# Altium schematic sheet ETHERNET.SchDoc(ETHERNET)
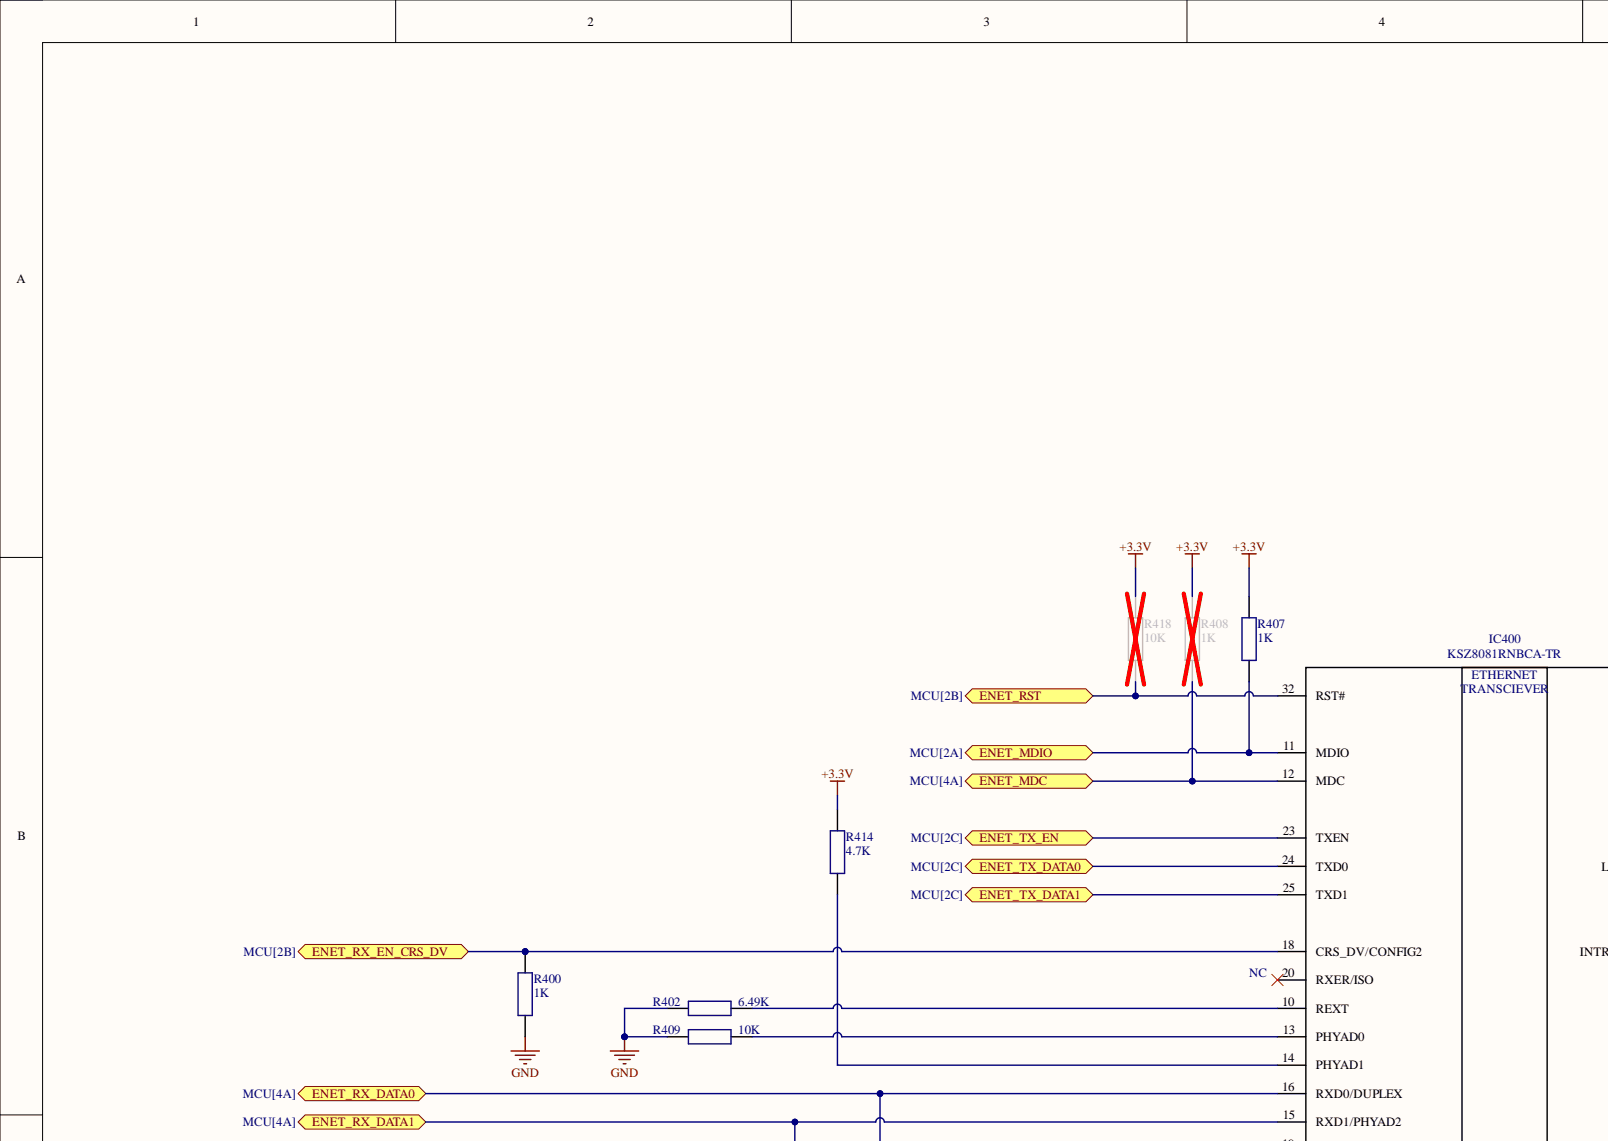
[diagram p0: ETHERNET.SchDoc, top left]
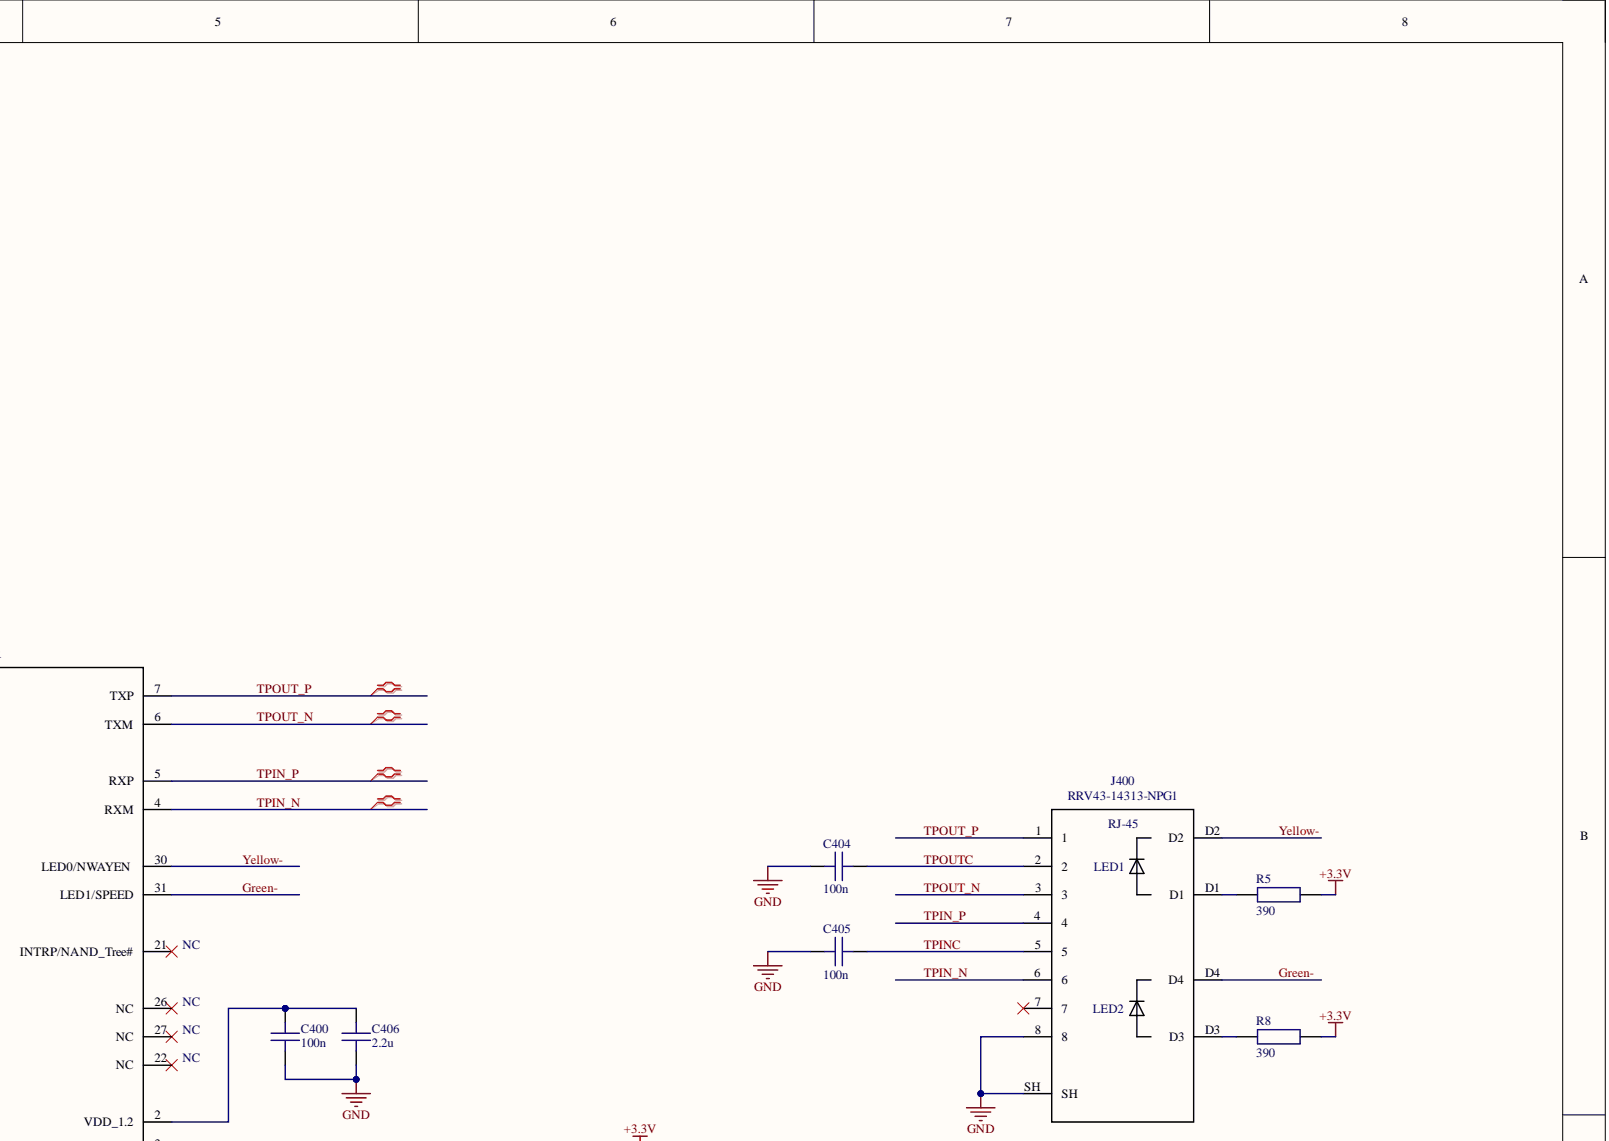
[diagram p1: ETHERNET.SchDoc, top right]
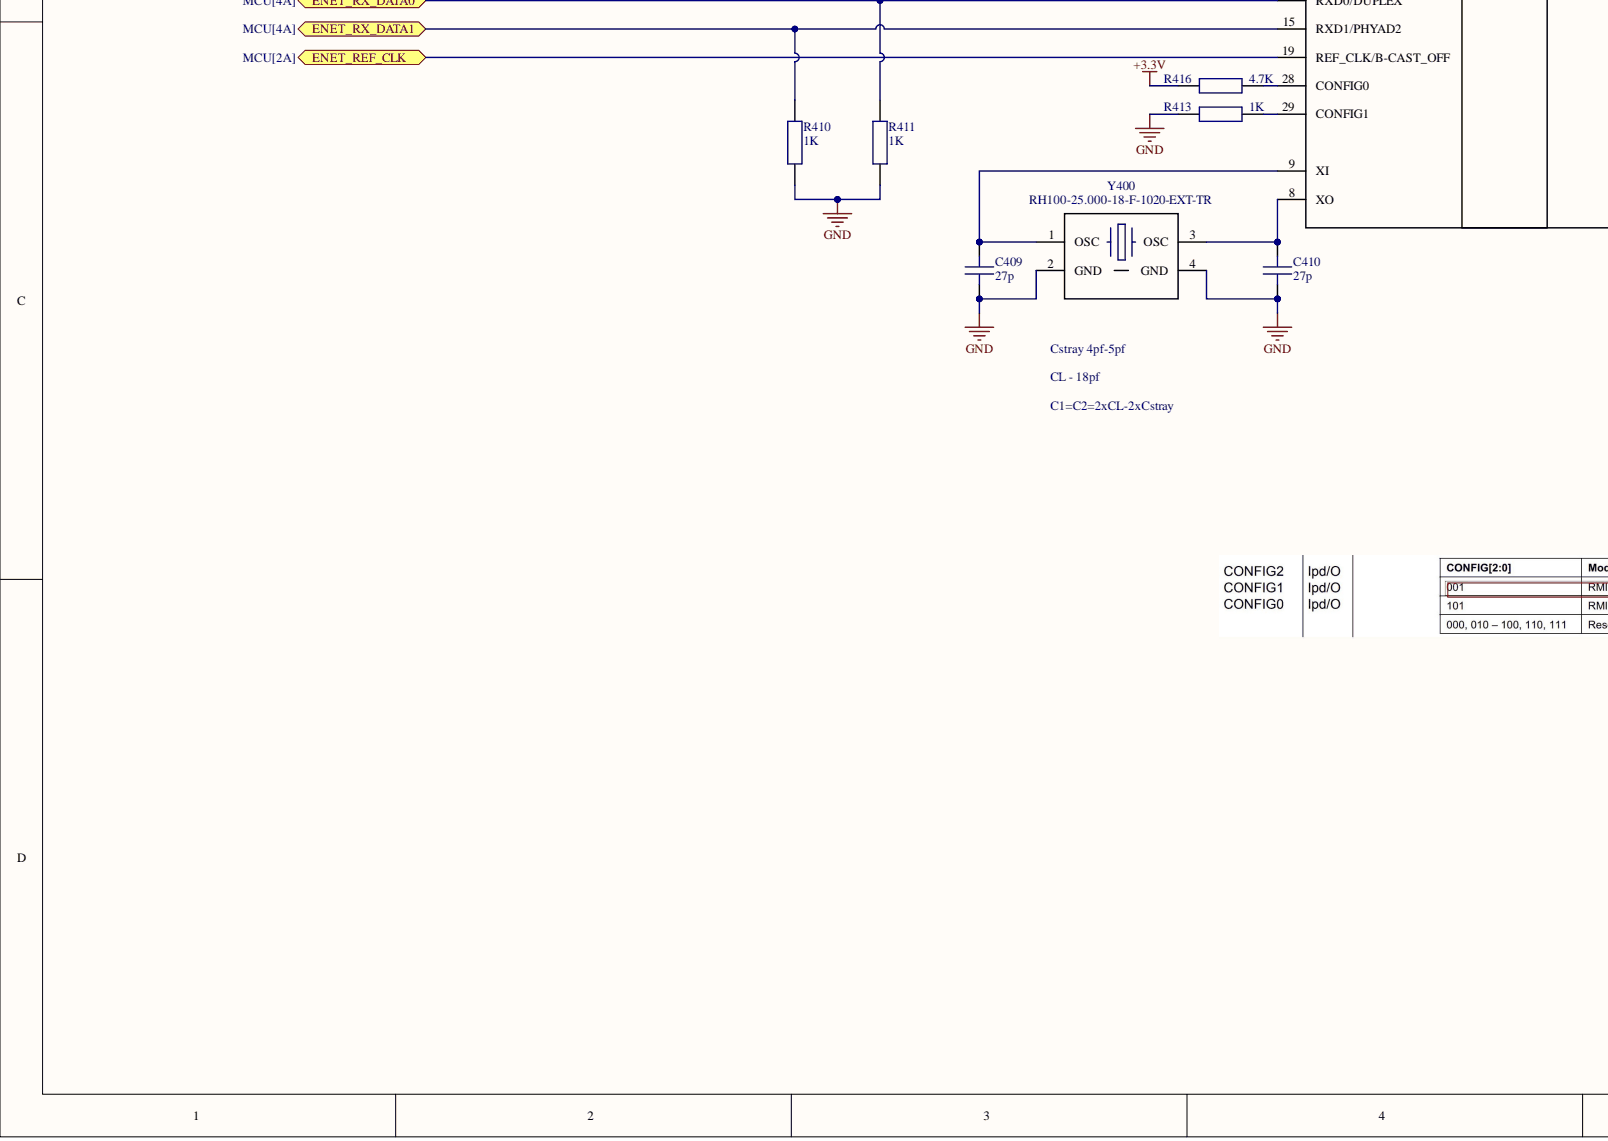
[diagram p2: ETHERNET.SchDoc, bottom left]
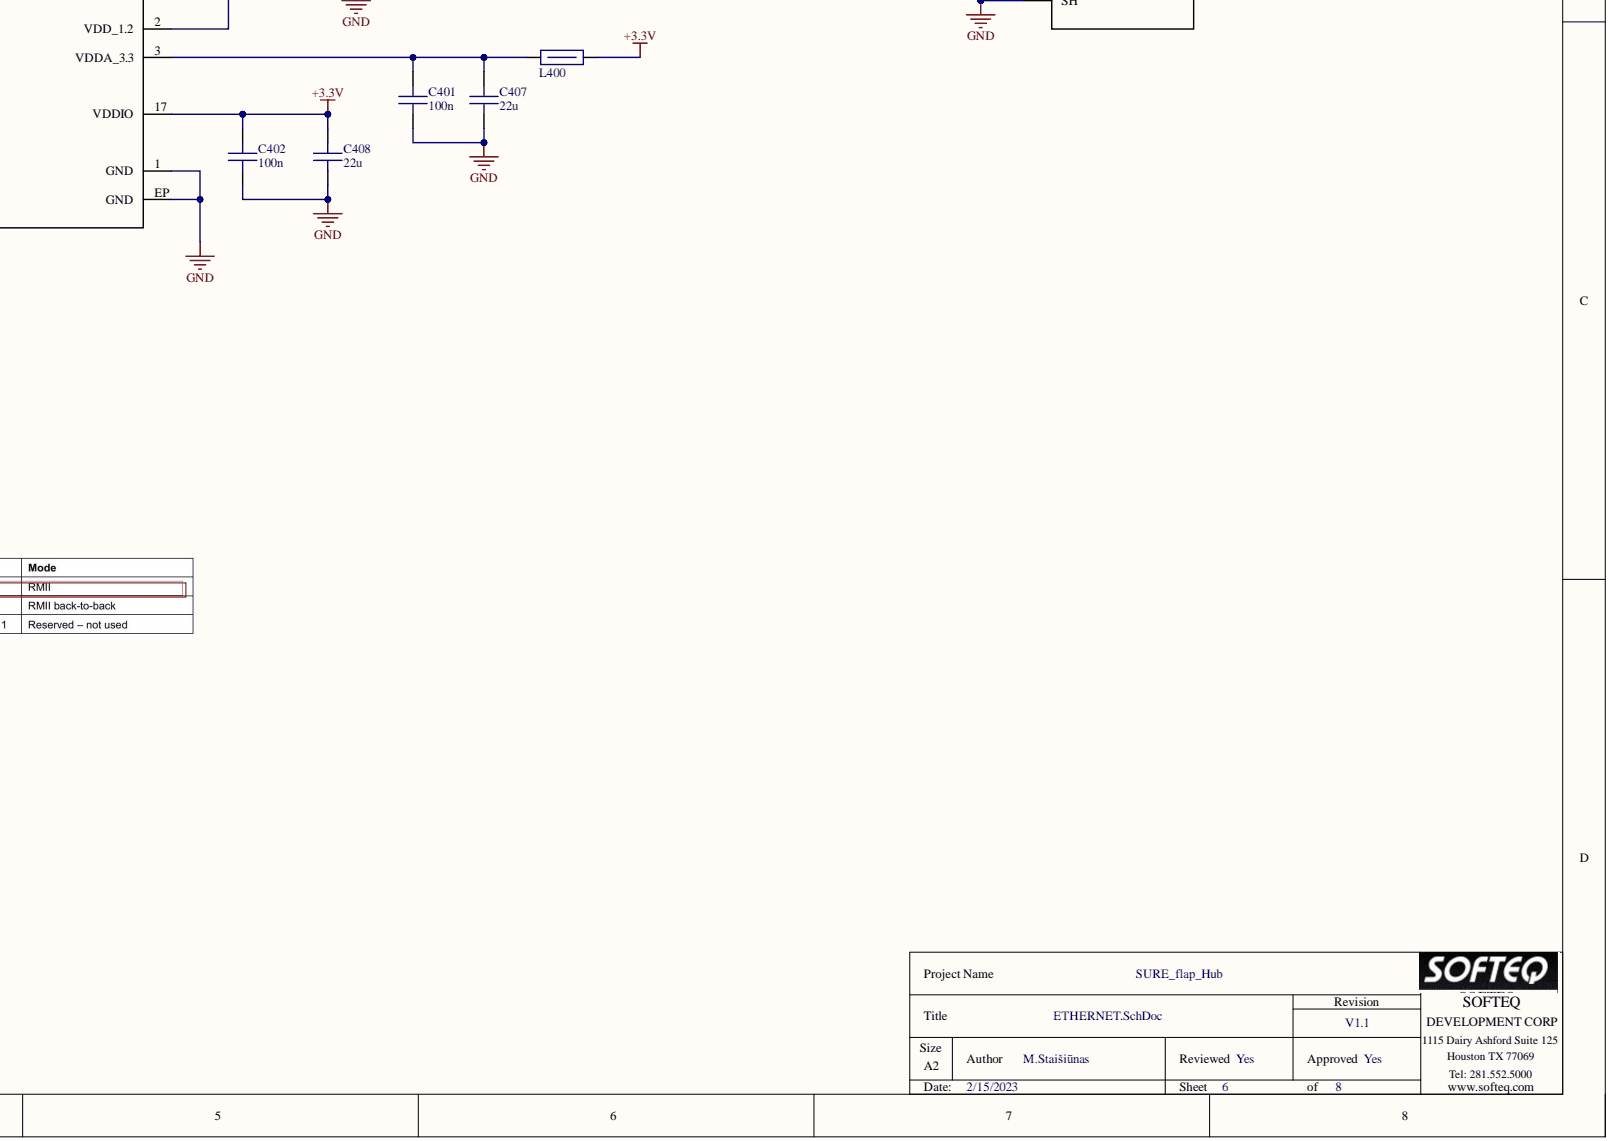
[diagram p3: ETHERNET.SchDoc, bottom right]

## Parts
C400  value 100n  [C_0402]  (hidden comment: CL05B104KO5NNNC)
C401  value 100n  [C_0402]  (hidden comment: CL05B104KO5NNNC)
C402  value 100n  [C_0402]  (hidden comment: CL05B104KO5NNNC)
C404  value 100n  [C_0402]  (hidden comment: CL05B104KO5NNNC)
C405  value 100n  [C_0402]  (hidden comment: CL05B104KO5NNNC)
C406  value 2.2u  [C_0402]  (hidden comment: CC0402KRX5R6BB225)
C407  value 22u  [C_0603]  (hidden comment: CL10A226MP8NUNE)
C408  value 22u  [C_0603]  (hidden comment: CL10A226MP8NUNE)
C409  value 27p  [C_0402]  (hidden comment: CC0402JRNPO9BN270)
C410  value 27p  [C_0402]  (hidden comment: CC0402JRNPO9BN270)
IC400  KSZ8081RNBCA-TR  [QFN-32[8x8]_0.30_0.50_5x5x1_EP[3.20x3.20]]
J400  RRV43-14313-NPG1  [REONG_RRV43-14313-NPG1_ALT]
L400  BLM15AG121SN1D  [MURATA_0402]  (value not drawn)
R5  value 390  [R_0402]  (hidden comment: RC0402FR-07390RL)
R8  value 390  [R_0402]  (hidden comment: RC0402FR-07390RL)
R400  value 1K  [R_0402]  (hidden comment: RC0402FR-071KL)
R402  value 6.49K  [R_0402]  (hidden comment: RC0402FR-076K49L)
R407  value 1K  [R_0402]  (hidden comment: RC0402FR-071KL)
R408  value 1K  [R_0402]  (hidden comment: RC0402FR-071KL)
R409  value 10K  [R_0402]  (hidden comment: RC0402FR-0710KL)
R410  value 1K  [R_0402]  (hidden comment: RC0402FR-071KL)
R411  value 1K  [R_0402]  (hidden comment: RC0402FR-071KL)
R413  value 1K  [R_0402]  (hidden comment: RC0402FR-071KL)
R414  value 4.7K  [R_0402]  (hidden comment: RC0402FR-074K7L)
R416  value 4.7K  [R_0402]  (hidden comment: RC0402FR-074K7L)
R418  value 10K  [R_0402]  (hidden comment: RC0402FR-0710KL)
Y400  RH100-25.000-18-F-1020-EXT-TR  [RALTRON_RH100-3225]

## Ports
ENET_MDC, ENET_MDIO, ENET_REF_CLK, ENET_RST, ENET_RX_DATA0, ENET_RX_DATA1, ENET_RX_EN_CRS_DV, ENET_TX_DATA0, ENET_TX_DATA1, ENET_TX_EN

## Nets
+3.3V: C402.2 C408.2 IC400.17(VDDIO) L400.1 R5.2 R8.2 R407.2 R408.2 R414.2 R416.2 R418.2
GND: C400.1 C401.1 C402.1 C404.1 C405.1 C406.1 C407.1 C408.1 C409.1 C410.1 IC400.1(GND) IC400.EP(GND) J400.8 J400.SH R400.1 R402.1 R409.1 R410.1 R411.1 R413.1 Y400.2(GND) Y400.4(GND)
ENET_MDC: IC400.12(MDC) R408.1 ; port ENET_MDC
ENET_MDIO: IC400.11(MDIO) R407.1 ; port ENET_MDIO
ENET_REF_CLK: IC400.19(REF_CLK/B-CAST_OFF) ; port ENET_REF_CLK
ENET_RST: IC400.32(RST#) R418.1 ; port ENET_RST
ENET_RX_DATA0: IC400.16(RXD0/DUPLEX) R411.2 ; port ENET_RX_DATA0
ENET_RX_DATA1: IC400.15(RXD1/PHYAD2) R410.2 ; port ENET_RX_DATA1
ENET_RX_EN_CRS_DV: IC400.18(CRS_DV/CONFIG2) R400.2 ; port ENET_RX_EN_CRS_DV
ENET_TX_DATA0: IC400.24(TXD0) ; port ENET_TX_DATA0
ENET_TX_DATA1: IC400.25(TXD1) ; port ENET_TX_DATA1
ENET_TX_EN: IC400.23(TXEN) ; port ENET_TX_EN
Green-: IC400.31(LED1/SPEED) J400.D4
TPIN_N: IC400.4(RXM) J400.6
TPIN_P: IC400.5(RXP) J400.4
TPINC: C405.2 J400.5
TPOUT_N: IC400.6(TXM) J400.3
TPOUT_P: IC400.7(TXP) J400.1
TPOUTC: C404.2 J400.2
Yellow-: IC400.30(LED0/NWAYEN) J400.D2
NetC400_2: C400.2 C406.2 IC400.2(VDD_1.2)
NetC401_2: C401.2 C407.2 IC400.3(VDDA_3.3) L400.2
NetC409_2: C409.2 IC400.9(XI) Y400.1(OSC)
NetC410_2: C410.2 IC400.8(XO) Y400.3(OSC)
NetIC400_10: IC400.10(REXT) R402.2
NetIC400_13: IC400.13(PHYAD0) R409.2
NetIC400_14: IC400.14(PHYAD1) R414.1
NetIC400_28: IC400.28(CONFIG0) R416.1
NetIC400_29: IC400.29(CONFIG1) R413.2
NetJ400_D1: J400.D1 R5.1
NetJ400_D3: J400.D3 R8.1

Not connected: IC400 20(RXER/ISO) 21(INTRP/NAND_Tree#) 22(NC) 26(NC) 27(NC); J400 7

## Library data of the parts
C400-C402 C404 C405 = CL05B104KO5NNNC: Capacitor, 100nF, 16V, X7R, 10%, -55/+125, 0402; Manufacturer: Samsung; Database Table Name: Capacitors; Component Kind: Standard; ComponentHeight: 0.55mm; Library Name: Library.dblib; Mounted: Yes; PartNumber: CL05B104KO5NNNC; SMD: Yes; Socket: No; Author: KAI
C406 = CC0402KRX5R6BB225: Capacitor, 2.2uF, 10V, X5R, 10%, -55/+85, 0402; Manufacturer: Yageo; Database Table Name: Capacitors; Component Kind: Standard; ComponentHeight: 0.5mm; Library Name: Library.dblib; Mounted: Yes; PartNumber: CC0402KRX5R6BB225; SMD: Yes; Author: KAI
C407 C408 = CL10A226MP8NUNE: Capacitor, 22uF, 10V, X5R, 20%, -55/+85, 0603; Manufacturer: Samsung; Database Table Name: Capacitors; Component Kind: Standard; ComponentHeight: 0.8mm; Library Name: Library.dblib; Mounted: Yes; PartNumber: CL10A226MP8NUNE; SMD: Yes; Author: ChAl
C409 C410 = CC0402JRNPO9BN270: Capacitor, 27pF, 50V, NP0, 5%, -55/+125, 0402; Manufacturer: Yageo; Database Table Name: Capacitors; Component Kind: Standard; ComponentHeight: 0.5mm; Library Name: Library.dblib; Mounted: Yes; PartNumber: CC0402JRNPO9BN270; SMD: Yes; Author: KAI
IC400 = KSZ8081RNBCA-TR: IC, 10/100BASE-T TX, Ethernet PHY, RMII, 0/+70, QFN-32; Manufacturer: Microchip; Database Table Name: IC Digital; Component Kind: Standard; ComponentHeight: 1mm; Library Name: Library.dblib; Mounted: Yes; PartNumber: KSZ8081RNBCA-TR; SMD: Yes; Author: DZ
J400 = RRV43-14313-NPG1: Conn, RJ-45, RA, 1POS, Shielded, 0/+75, TH; Manufacturer: REONG; Database Table Name: Connectors; Component Kind: Standard; ComponentHeight: 17.15mm; Library Name: Library.dblib; Mounted: Yes; PartNumber: RRV43-14313-NPG1; SMD: No; Author: DZ
L400 = BLM15AG121SN1D: Ferrite Bead, 120Ohm/100MHz, 25%, 550mA, -55/+125, 0402; Manufacturer: Murata; Database Table Name: Inductor_Transformer; Resistance: 120; Component Kind: Standard; ComponentHeight: 0.55mm; Library Name: Library.dblib; Mounted: Yes; PartNumber: BLM15AG121SN1D; Power: 550mA; SMD: Yes; Tolerance: ±25%; Author: DZ
R5 R8 = RC0402FR-07390RL: Resistor, 390, 1%, 0.0625W, -55/+155, 0402; Manufacturer: Yageo; Database Table Name: Resistors; Component Kind: Standard; ComponentHeight: 0.4mm; Library Name: Library.dblib; Mounted: Yes; PartNumber: RC0402FR-07390RL; SMD: Yes; Author: KAI
R400 R407 R408 R410 R411 R413 = RC0402FR-071KL: Resistor, 1K, 1%, 0.063W, -55/+155, 0402; Manufacturer: Yageo; Database Table Name: Resistors; Component Kind: Standard; ComponentHeight: 0.4mm; Library Name: Library.dblib; Mounted: Yes; PartNumber: RC0402FR-071KL; SMD: Yes; Author: KAI
R402 = RC0402FR-076K49L: Resistor, 6.49kOhm, 1%, 0.1W, -55/+155, 0402; Manufacturer: Yageo; Database Table Name: Resistors; Component Kind: Standard; ComponentHeight: 0.4mm; Library Name: Library.dblib; Mounted: Yes; PartNumber: RC0402FR-076K49L; SMD: Yes; Author: DZ
R409 R418 = RC0402FR-0710KL: Resistor, 10K, 1%, 0.063W, -55/+155, 0402; Manufacturer: Yageo; Database Table Name: Resistors; Component Kind: Standard; ComponentHeight: 0.4mm; Library Name: Library.dblib; Mounted: Yes; PartNumber: RC0402FR-0710KL; SMD: Yes; Author: KAI
R414 R416 = RC0402FR-074K7L: Resistor, 4.7K, 1%, 0.063W, -55/+155, 0402; Manufacturer: Yageo; Database Table Name: Resistors; Component Kind: Standard; ComponentHeight: 0.4mm; Library Name: Library.dblib; Mounted: Yes; PartNumber: RC0402FR-074K7L; SMD: Yes; Author: KAI
Y400 = RH100-25.000-18-F-1020-EXT-TR: Crystal, 25MHz, 20ppm, 18pF, -40/+85, 3.20x2.50x0.7mm, SMD; Manufacturer: Raltron; Database Table Name: Resonators_Generator; Component Kind: Standard; ComponentHeight: 0.8mm; Library Name: Library.dblib; Mounted: Yes; PartNumber: RH100-25.000-18-F-1020-EXT-TR; SMD: Yes; Value: 25MHz; Author: AlYu
